# Altium schematic sheet control_module.SchDoc
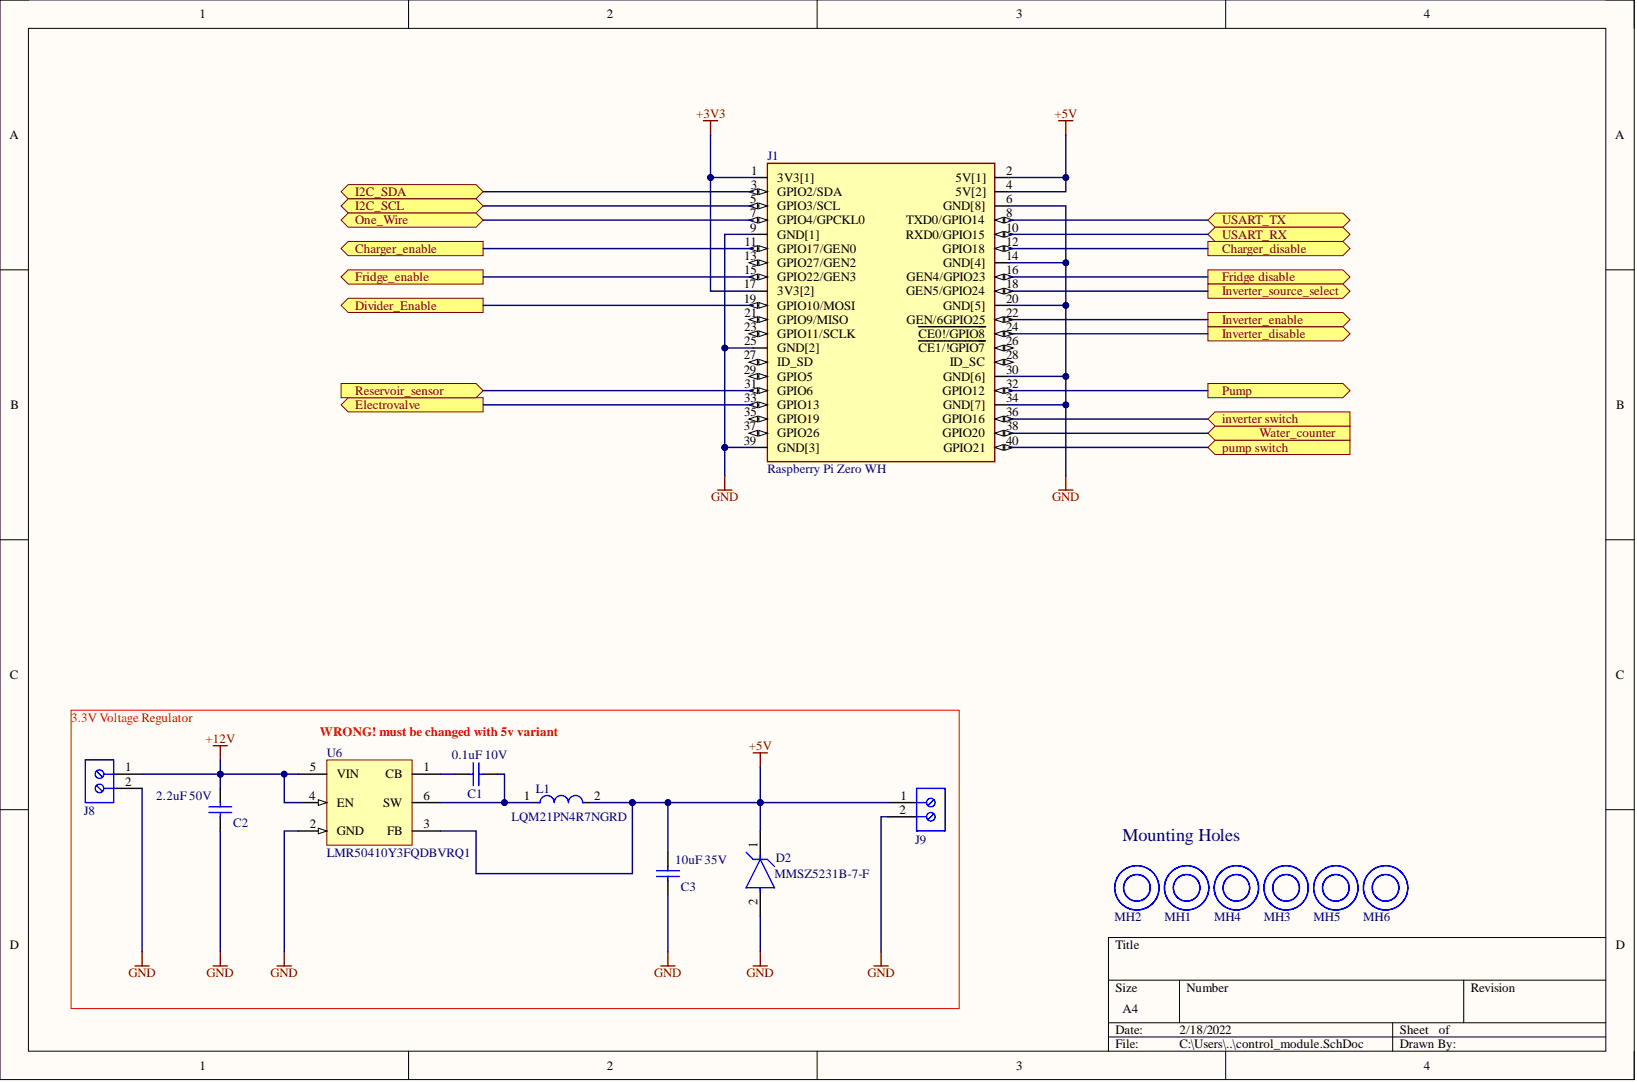
[diagram p0: control_module.SchDoc]

## Parts
C1  0.1uF 10V  [CAPC2013X88X50ML20T25]
C2  2.2uF 50V  [CAPC2013X88X50ML20T25]
C3  10uF 35V  [CAPC2013X88X50ML20T25]
D2  MMSZ5231B-7-F  [FP-SOD123-MFG]
J1  Raspberry Pi Zero WH  MF: Raspberry Pi  [raspberry_zero]
J8  282836-2  [TECO-282836-2_V]  (value not drawn)
J9  282836-2  [TECO-282836-2_V]  (value not drawn)
L1  LQM21PN4R7NGRD  [FP-LQM21PH_G0-IPC_B]
MH1  MOUNTING_HOLE_M2  [MOUNTING_HOLE_M2]  (value not drawn)
MH2  MOUNTING_HOLE_M2  [MOUNTING_HOLE_M2]  (value not drawn)
MH3  MOUNTING_HOLE_M2  [MOUNTING_HOLE_M2]  (value not drawn)
MH4  MOUNTING_HOLE_M2  [MOUNTING_HOLE_M2]  (value not drawn)
MH5  MOUNTING_HOLE_M2  [MOUNTING_HOLE_M2]  (value not drawn)
MH6  MOUNTING_HOLE_M2  [MOUNTING_HOLE_M2]  (value not drawn)
U6  LMR50410Y3FQDBVRQ1  [3.3v_voltage_regulator]

## Ports
Charger_disable, Charger_enable, Divider_Enable, Electrovalve, Fridge disable, Fridge_enable, I2C_SCL, I2C_SDA, inverter switch, Inverter_disable, Inverter_enable, Inverter_source_select, One_Wire, Pump, pump switch, Reservoir_sensor, USART_RX, USART_TX, Water_counter

## Nets
+3V3: J1.1(3V3[1]) J1.17(3V3[2])
+5V: C3.1 D2.1 J1.2(5V[1]) J1.4(5V[2]) J9.1 L1.2 U6.3(FB)
+12V: C2.2 J8.1 U6.4(EN) U6.5(VIN)
GND: C2.1 C3.2 D2.2 J1.6(GND[8]) J1.9(GND[1]) J1.14(GND[4]) J1.20(GND[5]) J1.25(GND[2]) J1.30(GND[6]) J1.34(GND[7]) J1.39(GND[3]) J8.2 J9.2 U6.2(GND)
Charger_disable: J1.12(GPIO18) ; port Charger_disable
Charger_enable: J1.11(GPIO17/GEN0) ; port Charger_enable
Divider_Enable: J1.19(GPIO10/MOSI) ; port Divider_Enable
Electrovalve: J1.33(GPIO13) ; port Electrovalve
Fridge disable: J1.16(GEN4/GPIO23) ; port Fridge disable
Fridge_enable: J1.15(GPIO22/GEN3) ; port Fridge_enable
I2C_SCL: J1.5(GPIO3/SCL) ; port I2C_SCL
I2C_SDA: J1.3(GPIO2/SDA) ; port I2C_SDA
inverter switch: J1.36(GPIO16) ; port inverter switch
Inverter_disable: J1.24(CE0!/GPIO8) ; port Inverter_disable
Inverter_enable: J1.22(GEN/6GPIO25) ; port Inverter_enable
Inverter_source_select: J1.18(GEN5/GPIO24) ; port Inverter_source_select
One_Wire: J1.7(GPIO4/GPCKL0) ; port One_Wire
Pump: J1.32(GPIO12) ; port Pump
pump switch: J1.40(GPIO21) ; port pump switch
Reservoir_sensor: J1.31(GPIO6) ; port Reservoir_sensor
USART_RX: J1.10(RXD0/GPIO15) ; port USART_RX
USART_TX: J1.8(TXD0/GPIO14) ; port USART_TX
Water_counter: J1.38(GPIO20) ; port Water_counter
NetC1_1: C1.1 L1.1 U6.6(SW)
NetC1_2: C1.2 U6.1(CB)

Not connected: J1 13(GPIO27/GEN2) 21(GPIO9/MISO) 23(GPIO11/SCLK) 26(CE1/!GPIO7) 27(ID_SD) 28(ID_SC) 29(GPIO5) 35(GPIO19) 37(GPIO26)

## Library data of the parts
C1-C3 = Cap_0805: Capacitor; Component Kind: Standard; Library Name: Schlib.SchLib
D2 = CMP-12792-000005-1: DIODE ZENER 5.1V 500MW SOD123; Manufacturer: Diodes; Max Junction Temp: +150°C; Max Reverse Leakage Current: 5uA; Max Zener Voltage: 5.36V; Power Dissipation: 370mW; Standoff Height: 0mm; Zener Impedance: 17Ω; Automotive: No; Category: Diode; Component Kind: Standard; ComponentLink2Description: Datasheet; ComponentLink2URL: https://www.diodes.com/assets/Datasheets/ds18010.pdf; Device Class L1: Discrete Semiconductors; Device Class L2: Diodes; Device Class L3: Zener Diodes; Digikey Description: DIODE ZENER 5.1V 500MW SOD123; Footprint Url: https://www.diodes.com/assets/Package-Files/SOD123.pdf; Height: 1.45mm; Lead Free: Yes; Library Name: Altium Content Vault; Manufacturer Part Number: MMSZ5231B-7-F; Mouser Description: Zener Diodes 5.1V 500mW; Nom Zener Voltage: 5.1V; Octopart: https://octopart.com/mmsz5231b-7-f-diodes+inc.-55400050; Package: SOD123; RoHS: TRUE; Temperature: -65°C to +150°C; Temperature Range High: +150°C; Temperature Range Low: -65°C
J1 = RASPBERRY_PI_ZERO_WH: Raspberry Pi Zero WH with Headers; MP: ADA3708; Availability: Unavailable; Component Kind: Standard; Library Name: Schlib.SchLib; Package: None; Price: None; SnapEDA_Link: https://www.snapeda.com/parts/ADA3708/Raspberry%20Pi/view-part/2770118/?ref=snap
J8 J9 = CMP-1684-00017-1: Female Header, Pitch 5 mm, 1 x 2 Position, Height 10 mm, Tail Length 3.5 mm, Rohs, Bulk; Manufacturer: TE Connectivity; 3D Model: 282836-2.pdf; Clamp Material - Plating: Brass-NickelPlated; Current: 13.5A; Dynamic Catalog: BuchananSeries; Featured Product: EurostyleTerminalBlockConnectors; Mating Orientation: HorizontalwithBoard; Number of Levels: 1; Other Names: 5ULV-02B  A98076; Pitch: 0.197"(5.00mm); Positions Per Level: 2; Product Training Modules: Wire-to-BoardEurostyleTerminalBlocks; Screw Thread: M3; Torque - Screw: 0.68Nm(6Lb-In); Voltage: 300V; Wire Gauge: 16-30AWG; Wire Termination: Screw-RisingCageClamp; Category: Connectors,Interconnects; Color: Green; Component Kind: Standard; Contact Material - Plating: Brass-TinPlated; Datasheet URL: http://www.te.com.cn/commerce/DocumentDelivery/DDEController?Action=showdoc&DocId=Catalog+Section%7F1308389_EUROSTYLE_TERMINAL_BLOCKS%7F0607%7Fpdf%7FEnglish%7FENG_CS_1308389_EUROSTYLE_TERMINAL_BLOCKS_0607.pdf%7F282836-2; Family: TerminalBlocks-WiretoBoard; Features: Interlocking(Side); Housing Material: Polyamide(PA66),Nylon6/6; Library Name: Altium Content Vault; Manufacturer URL: http://www.te.com; Mounting Type: ThroughHole; Package Description: Female Header, Pitch 5 mm, 1 x 2 Position, Height 10 mm, Tail Length 3.5 mm; Package Reference: THD; Packaging: Bulk; RoHS Information: 282836-2StatementofCompliance; Screw Material - Plating: Brass-NickelPlated; Series: Buchanan; Standard Package: 300
L1 = CMP-06042-016903-1: Multilayer Type Inductor for Power Lines, 0805 (2012), 4.7μH, 30%, 0.288Ω, 0.8A; Core Material: Ferrite; Current Rating: 800mA; DC Resistance (DCR): 288mR; Inductance: 4.7uH; Self Resonant Frequency: 30MHz; Shielding: Shielded; Test Frequency: 1MHz; Case Code (Imperial): 0805; Case Code (Metric): 2012; Case/Package: 0805; Component Kind: Standard; DC Current: 800mA; Depth: 1.4mm; Height: 0.9mm; Height - Seated (Max): 0.039inch; Lead Free: Lead Free; Length: 0.079inch; Library Name: Altium Content Vault; Max DC Current: 800mA; Max Operating Temperature: 125°C; Military Standard: Not; Min Operating Temperature: -55°C; Mount: Surface Mount; Package Quantity: 4000; Packaging: Tape and Reel; Radiation Hardening: No; RoHS Compliant: Yes; Series: LQM21PN_GR; Termination: SMD/SMT; Tolerance: 30%; Width: 1.25mm
U6 = LMR50410Y3FQDBVRQ1: 3,3V voltage regulator; Manufacturer: Texas Instruments; Component Kind: Standard; Library Name: Schlib.SchLib; Manufacturer Part Number: LMR50410Y3FQDBVRQ1; Pricing 1: 1=1.65000, 10=1.47600, 25=1.40080, 100=1.07570, 250=0.95060, 500=0.90058, 1000=0.70045 (USD); RoHS: Yes; Stock 1: 2682; Supplier 1: Digi-Key; Supplier Part Number 1: 296-LMR50410Y3FQDBVRQ1CT-ND
MH1-MH6 = MOUNTING_HOLE_M2: Component Kind: Standard; Library Name: integrated_library.IntLib
